# Altium schematic sheet USB_data.SchDoc
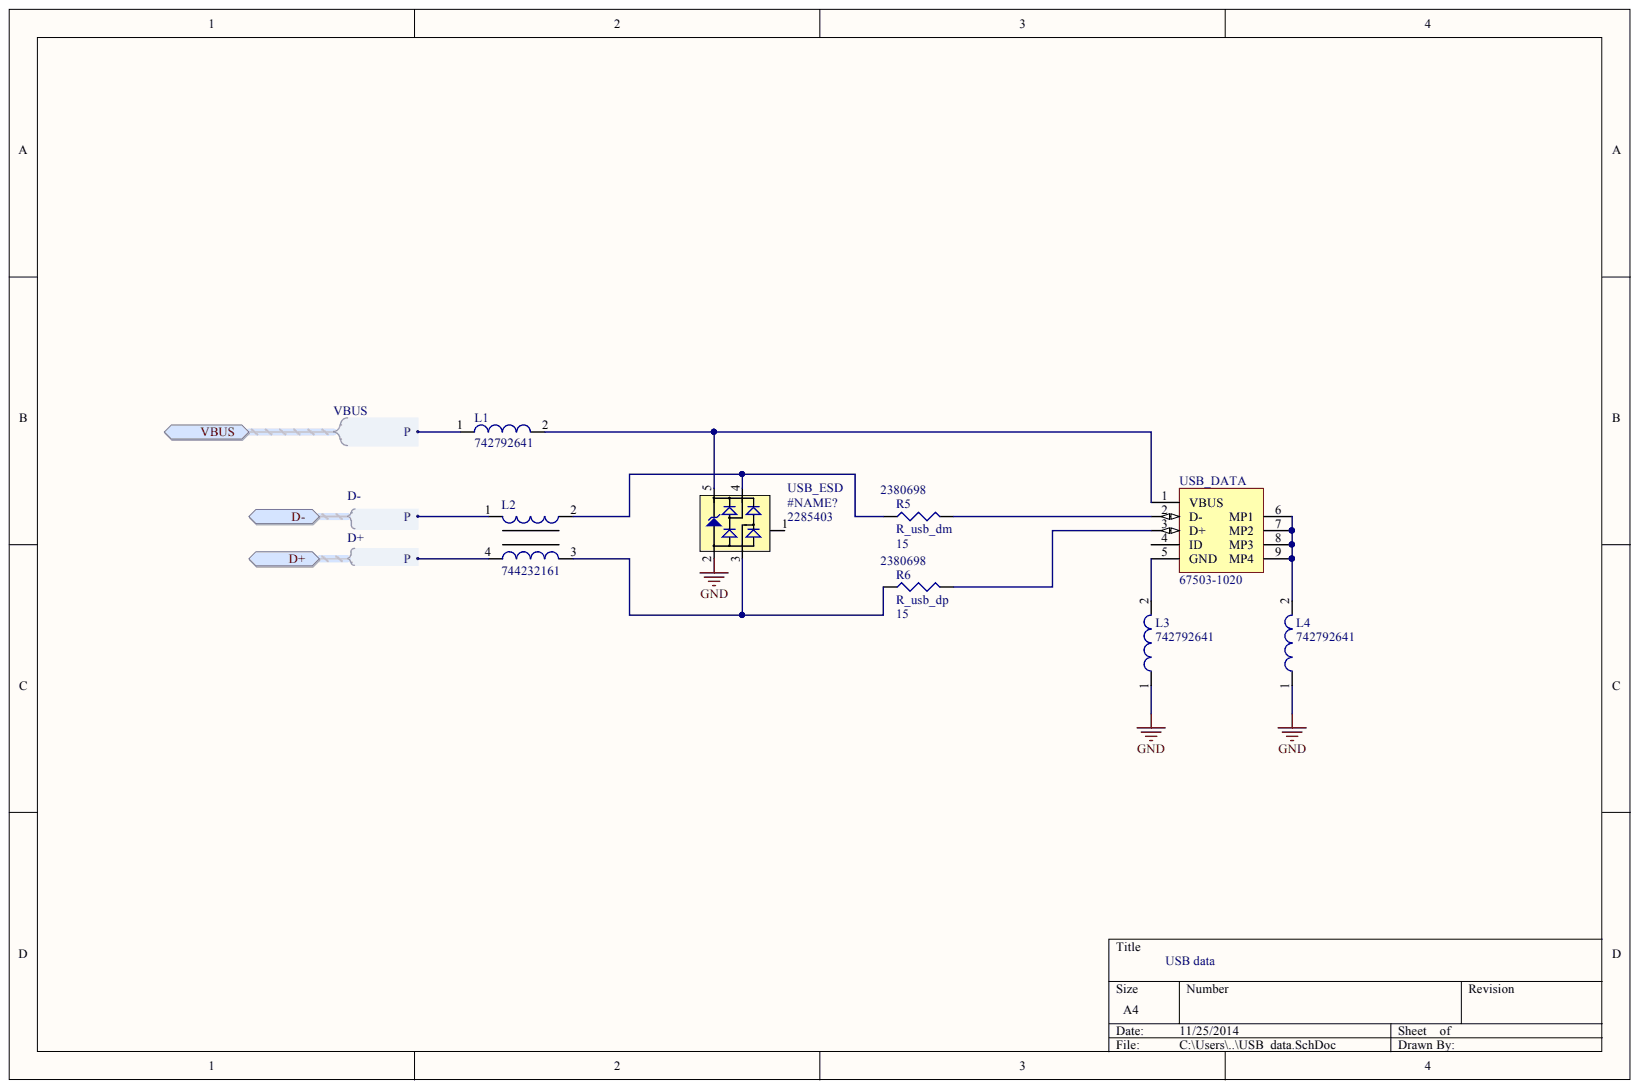
[diagram p0: USB_data.SchDoc]

## Parts
L1  742792641  [SMD-0603]
L2  744232161  [WE-CNSW-1206]
L3  742792641  [SMD-0603]
L4  742792641  [SMD-0603]
R5  R_usb_dm  value 15  Supplier Part Number 1: 2380698  [14-1210]
R6  R_usb_dp  value 15  Supplier Part Number 1: 2380698  [14-1210]
USB_DATA  67503-1020  [MOLX-67503-1020_V]
USB_ESD  #NAME?  Supplier Part Number 1: 2285403  [SOT95P275X140-5N]

## Ports
D+, D+.P, D-, D-.P, VBUS, VBUS.P

## Nets
GND: L3.1 L4.1 USB_ESD.2
VBUS: L1.1 ; port VBUS
NetL1_2: L1.2 USB_DATA.1(VBUS) USB_ESD.5
NetL2_1: L2.1
NetL2_2: L2.2 R5.1 USB_ESD.4
NetL2_3: L2.3 R6.1 USB_ESD.3
NetL2_4: L2.4
NetL3_2: L3.2 USB_DATA.5(GND)
NetL4_2: L4.2 USB_DATA.6(MP1) USB_DATA.7(MP2) USB_DATA.8(MP3) USB_DATA.9(MP4)
NetR5_2: R5.2 USB_DATA.2(D-)
NetR6_2: R6.2 USB_DATA.3(D+)

Not connected: USB_DATA 4(ID); USB_ESD 1

## Library data of the parts
L1 L3 L4 = CMP-0220-00171-1: SMD EMI Suppression Ferrite Bead WE-CBF, Z = 300 Ohm; Impedance: 300 Ohm; Component Kind: Standard; ComponentLink1Description: Manufacturer Link; ComponentLink1URL: http://www.we-online.com; ComponentLink2Description: Datasheet; ComponentLink2URL: http://www.we-online.de/katalog/media/pdf/742792641.pdf; DatasheetVersion: Dec-2005; Library Name: Altium Content Vault; PackageDescription: Chip Inductor, 2-Leads, Body 1.6 x 0.8 mm; PackageReference: SMD-0603; PackageVersion: 2009
L2 = CMP-0222-00033-1: SMD Common Mode Noise Suppressor WE-CNSW, Z = 160 Ohm; Impedance: 160 Ohm; Component Kind: Standard; ComponentLink1Description: Manufacturer Link; ComponentLink1URL: http://www.we-online.com; ComponentLink2Description: Datasheet; ComponentLink2URL: http://www.we-online.de/katalog/media/pdf/744232161.pdf; DatasheetVersion: ver 3, Oct-2004; Library Name: Altium Content Vault; PackageDescription: SMD Inductor, 4-Leads, Body 1.8 x 3.4 mm; PackageReference: WE-CNSW-1206; PackageVersion: 2004
R5 R6 = Res3: Resistor; Manufacturer: PANASONIC; Manufacturer Part Number: ERJU14F15R0U; Power Rating: 500; Product Range: PANASONIC - ERJ Series; Resistance Tolerance: ± 1%; Resistor Case Style: 1210 [3225 Metric]; Resistor Element Material: Thick Film; SVHC: No SVHC (16-Dec-2013; Temperature Coefficient: ± 100; Voltage Rating: 200; Component Kind: Standard; ComponentLink1Description: http://www.farnell.com/datasheets/1783557.pdf; ComponentLink1URL: http://www.farnell.com/datasheets/1783557.pdf; LatestRevisionDate: 29-May-2009; LatestRevisionNote: IPC-7351 Footprint Added.; Library Name: Miscellaneous Devices.IntLib; MSL: -; PackageDescription: Chip Resistor; PackageReference: J1-0603; Packaging: Cut Tape; Pricing 1: 10=1,05, 100=0,951, 500=0,734, 1000=0,589, 5000=0,434 (EUR); Published: 8-Jun-2000; Resistance: 15; RoHS: YES; Signal Integrity: Res; Simulation: RESISTOR; Stock 1: 3740; Supplier 1: Farnell; Publisher: Altium Limited
USB_DATA = CMP-0411-00002-1: USB, MINI, SMD, RA KME04-USBMU03A01; Manufacturer: Molex; Component Kind: Standard; ComponentLink1Description: Manufacturer URL; ComponentLink1URL: http://www.molex.com/; ComponentLink2Description: Datasheet; ComponentLink2URL: http://www.molex.com/pdm_docs/sd/675031020_sd.pdf; DatasheetVersion: Rev F, 06/2009; Library Name: Altium Content Vault; Mounting Technology: Surface Mount; PackageDescription: Mini USB Connector, SMT, Righer Angle; PackageReference: 67503-1020; PartNumber: 67503-1020; Terminal Pitch: 0.8 mm
USB_ESD = WE-TVS-HS,SOT23-5L,824011: TVS Diode WE-TVS-HS, VRWM=5V; Manufacturer: Würth Elektronik eiSos GmbH; Manufacturer Part Number: DZ5X068D0R; PartNo: 824011; Reverse Stand Off Voltage: 5V; Component Kind: Standard; ComponentLink1Description: Manufacturer; ComponentLink1URL: http://www.we-online.de; ComponentLink2Description: Datasheet; ComponentLink2URL: http://katalog.we-online.de/kataloge/eisos/media/pdf/824011.pdf; ComponentLink3Description: http://www.semicon.panasonic.co.jp/ds4/DZ5X068D_BED.pdf; ComponentLink3URL: http://www.semicon.panasonic.co.jp/ds4/DZ5X068D_BED.pdf; Created Date: March 2010; Library Name: WE-TVS.intlib; Pricing 1: 1=1,2, 25=0,93, 100=0,77, 500=0,74, 1000=0,73, 3000=0,71, 3000=0,639, 40000=0,615 (EUR); RoHS: YES; Stock 1: 901; Supplier 1: Farnell; Created by: Christin Zierenner
